# Altium schematic sheet C.ELI_Con_L.SchDoc
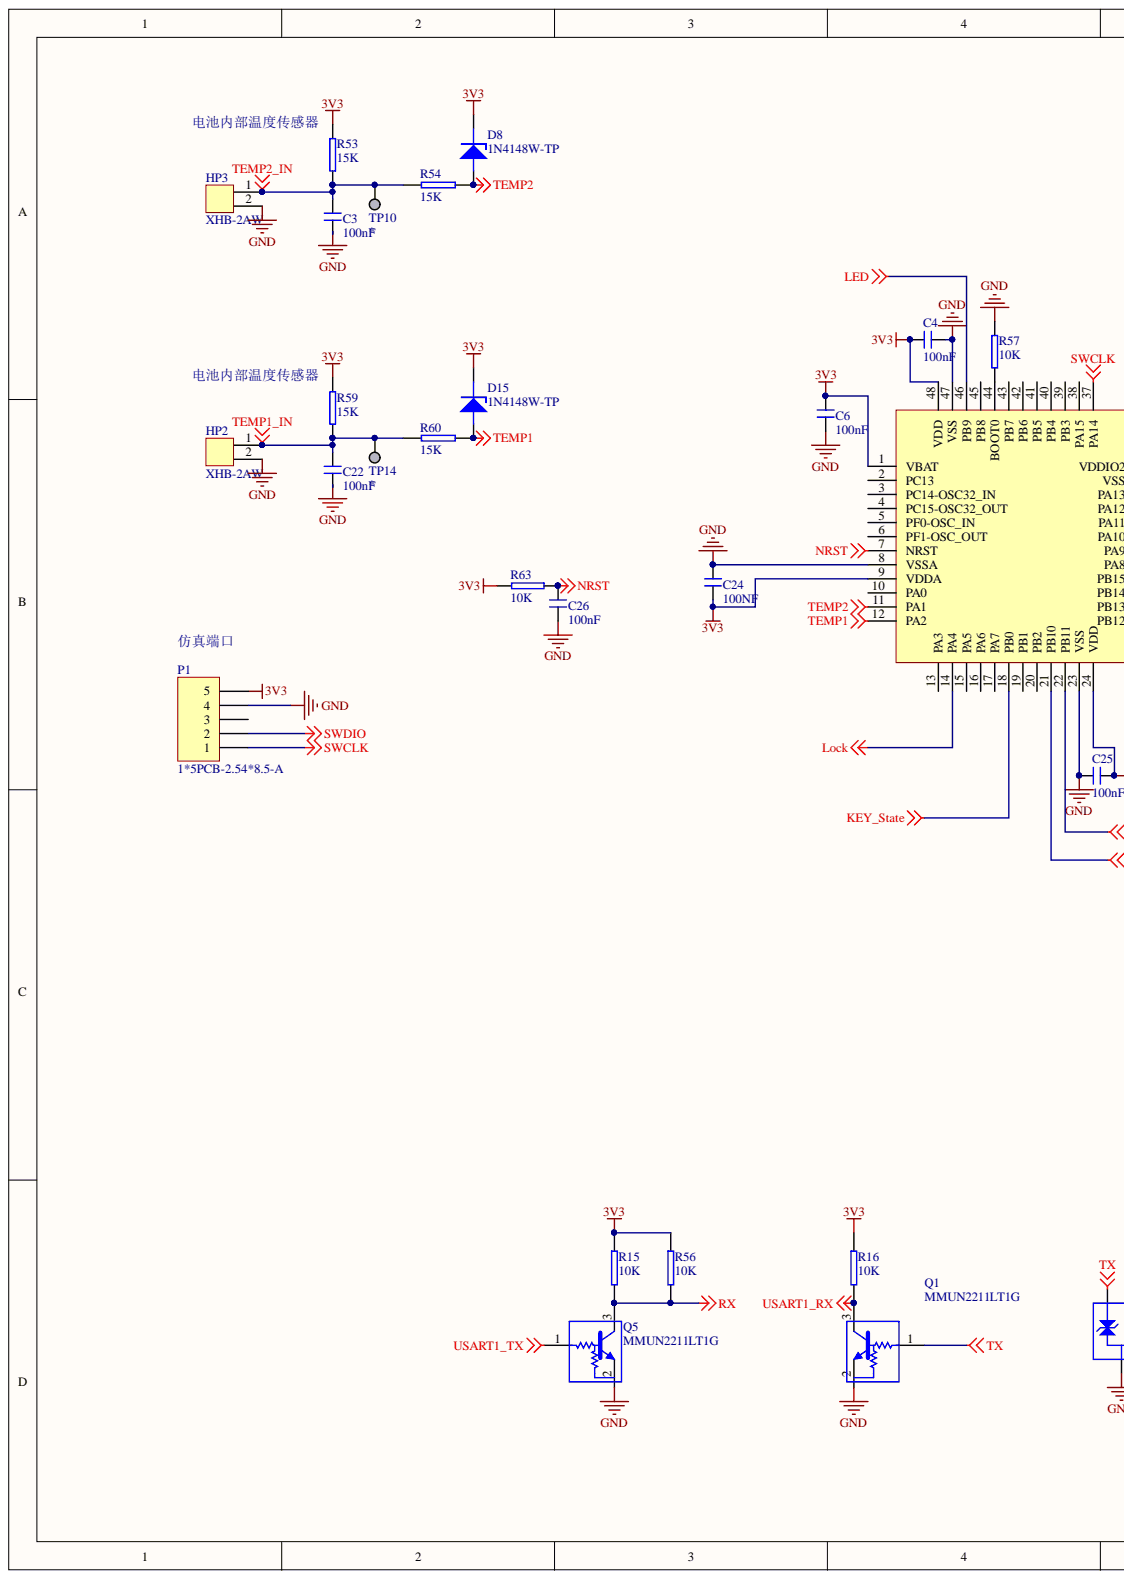
[diagram p0: C.ELI_Con_L.SchDoc, left]
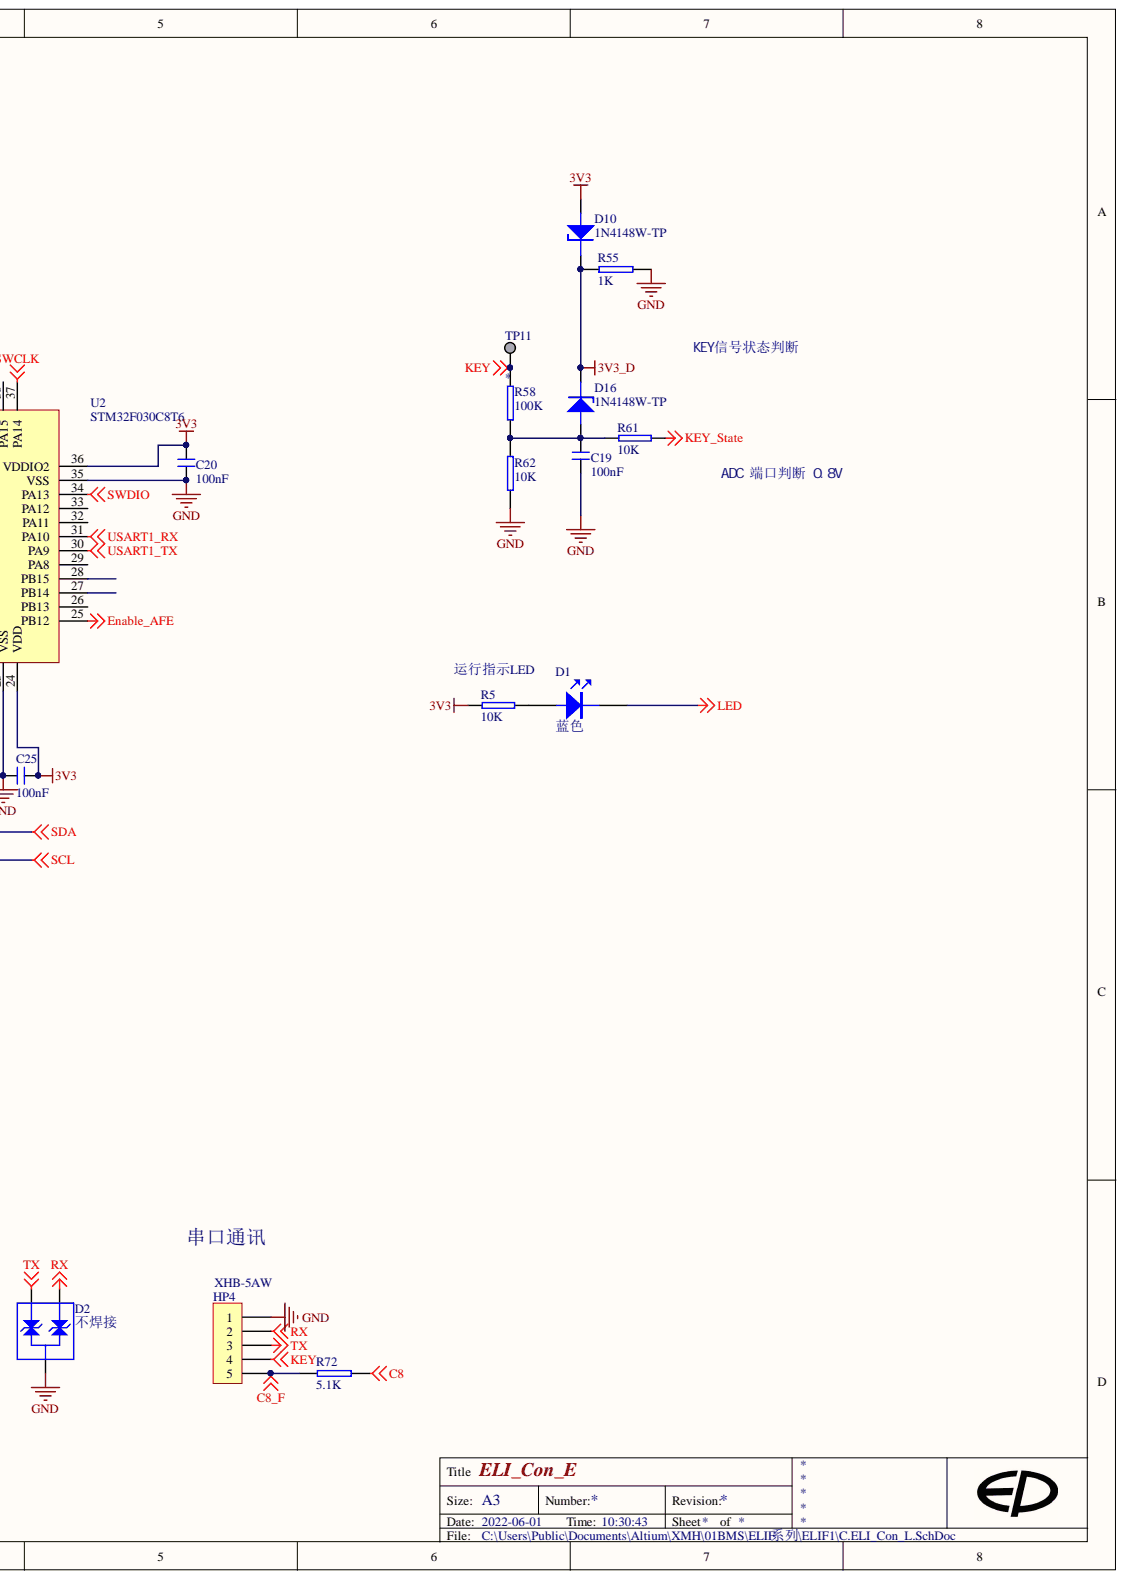
[diagram p1: C.ELI_Con_L.SchDoc, right]

## Parts
C3  100nF  [0603-C]
C4  100nF  [0603-C]
C6  100nF  [0603-C]
C19  100nF  [0603-C]
C20  100nF  [0603-C]
C22  100nF  [0603-C]
C24  100NF  [0603-C]
C25  100nF  [0603-C]
C26  100nF  [0603-C]
D1  蓝色  [0805led]
D2  不焊接  [SOT-23M]
D8  1N4148W-TP  [SOD-123]
D10  1N4148W-TP  [SOD-123]
D15  1N4148W-TP  [SOD-123]
D16  1N4148W-TP  [SOD-123]
HP2  XHB-2AW  [XH2.54-2P-C]
HP3  XHB-2AW  [XH2.54-2P-C]
HP4  XHB-5AW  [XH2.54-5P-C]
P1  1*5PCB-2.54*8.5-A  [HDR1X5]
Q1  MMUN2211LT1G  [SOT-23S]
Q5  MMUN2211LT1G  [SOT-23S]
R5  10K  [0603-R]
R15  10K  [0603-R]
R16  10K  [0603-R]
R53  15K  [0603-R]
R54  15K  [0603-R]
R55  1K  [0805-R]
R56  10K  [0603-R]
R57  10K  [0603-R]
R58  100K  [0603-R]
R59  15K  [0603-R]
R60  15K  [0603-R]
R61  10K  [0603-R]
R62  10K  [0603-R]
R63  10K  [0603-R]
R72  5.1K  [1206 -R]
TP10  (no value)  [TESTPOINT50]
TP11  (no value)  [TESTPOINT50]
TP14  (no value)  [TESTPOINT50]
U2  STM32F030C8T6  [48NA]

## Nets
3V3: C4.2 C6.1 C20.1 C24.2 C25.1 D8.1 D10.2 D15.1 P1.5 R5.2 R15.2 R16.2 R53.1 R56.2 R59.1 R63.1 U2.1(VBAT) U2.9(VDDA) U2.24(VDD) U2.36(VDDIO2) U2.48(VDD)
3V3_D: D10.1 D16.1 R55.2
C8: R72.2
C8_F: HP4.5 R72.1
Enable_AFE: U2.25(PB12)
GND: C3.2 C4.1 C6.2 C19.2 C20.2 C22.2 C24.1 C25.2 C26.2 D2.3 HP2.2 HP3.2 HP4.1 P1.4 Q1.2 Q5.2 R55.1 R57.2 R62.1 U2.8(VSSA) U2.23(VSS) U2.35(VSS) U2.47(VSS)
KEY: HP4.4 R58.1 TP11.1
KEY_State: R61.2 U2.18(PB0)
LED: D1.2 U2.46(PB9)
Lock: U2.14(PA4)
NRST: C26.1 R63.2 U2.7(NRST)
RX: D2.1 HP4.2 Q5.3 R15.1 R56.1
SCL: U2.21(PB10)
SDA: U2.22(PB11)
SWCLK: P1.1 U2.37(PA14)
SWDIO: P1.2 U2.34(PA13)
TEMP1: D15.2 R60.2 U2.12(PA2)
TEMP1_IN: C22.1 HP2.1 R59.2 R60.1 TP14.1
TEMP2: D8.2 R54.2 U2.11(PA1)
TEMP2_IN: C3.1 HP3.1 R53.2 R54.1 TP10.1
TX: D2.2 HP4.3 Q1.1
USART1_RX: Q1.3 R16.1 U2.31(PA10)
USART1_TX: Q5.1 U2.30(PA9)
NetC19_1: C19.1 D16.2 R58.2 R61.1 R62.2
NetD1_1: D1.1 R5.1
NetR57_1: R57.1 U2.44(BOOT0)

Not connected: P1 3; U2 2(PC13) 3(PC14-OSC32_IN) 4(PC15-OSC32_OUT) 5(PF0-OSC_IN) 6(PF1-OSC_OUT) 10(PA0) 13(PA3) 15(PA5) 16(PA6) 17(PA7) 19(PB1) 20(PB2) 26(PB13) 27(PB14) 28(PB15) 29(PA8) 32(PA11) 33(PA12) 38(PA15) 39(PB3) 40(PB4) 41(PB5) 42(PB6) 43(PB7) 45(PB8)

## Library data of the parts
C3 C4 C6 C19 C20 C22 C24-C26 = Cap: Component Kind: Standard; Library Name: xmh_c.SchLib
  C3 C4 C6 C19 C20 C22 C25 C26: Value: 100nF
  C24: Value: 100NF
D1 = LED: 发光二极管; Component Kind: Standard; Library Name: xmh_c.IntLib
D2 = NUP2105LT: 贴片二极管; Component Kind: Standard; Value: 不焊接; Library Name: xmh_c.IntLib
D8 D10 D15 D16 = DIODE: 二极管; Component Kind: Standard; Value: 1N4148W-TP; Library Name: xmh_c.SchLib
HP2 HP3 = H2P: 端子; Component Kind: Standard; Library Name: xmh_c.IntLib
HP4 = XH-5P: Component Kind: Standard; Library Name: xmh_c.IntLib
P1 = Header 5: Header, 5-Pin; Component Kind: Standard; LatestRevisionDate: 17-Jul-2002; LatestRevisionNote: Re-released for DXP Platform.; Library Name: Miscellaneous Connectors.IntLib; Signal Integrity: Connector; Publisher: Altium Limited
Q1 Q5 = NPN_R: 贴片三极管; Parameter 1: PDTC114ET,215; Component Kind: Standard; Parameter 2: MMUN2211LT1G; Value: MMUN2211LT1G; Library Name: xmh_c.IntLib
R5 R53 R54 R57 R59-R61 R63 = RES T: 电阻; Component Kind: Standard
  R5 R57 R61 R63: Value: 10K
  R53 R54 R59 R60: Value: 15K
  R5 R53 R57 R59 R63: Library Name: xmh_c.SchLib
  R54 R60 R61: Library Name: xmh_c.IntLib
R15 R16 R55 R56 R58 R62 R72 = RES T: 贴片电阻; Component Kind: Standard; Library Name: xmh_c.IntLib
  R15 R16 R56 R62: Value: 10K
  R55: Value: 1K
  R58: Value: 100K
  R72: Value: 5.1K
TP10 TP11 TP14 = TESTPOINT: TEST POINT.  No entry in BOM.; Component Kind: Standard; Library Name: xmh_c.IntLib
U2 = STM32F072C8: Component Kind: Standard; Library Name: xmh_c.SchLib
